# Altium schematic sheet Sheet 2 - Moteurs.SchDoc("Sheet 2 - Moteurs")
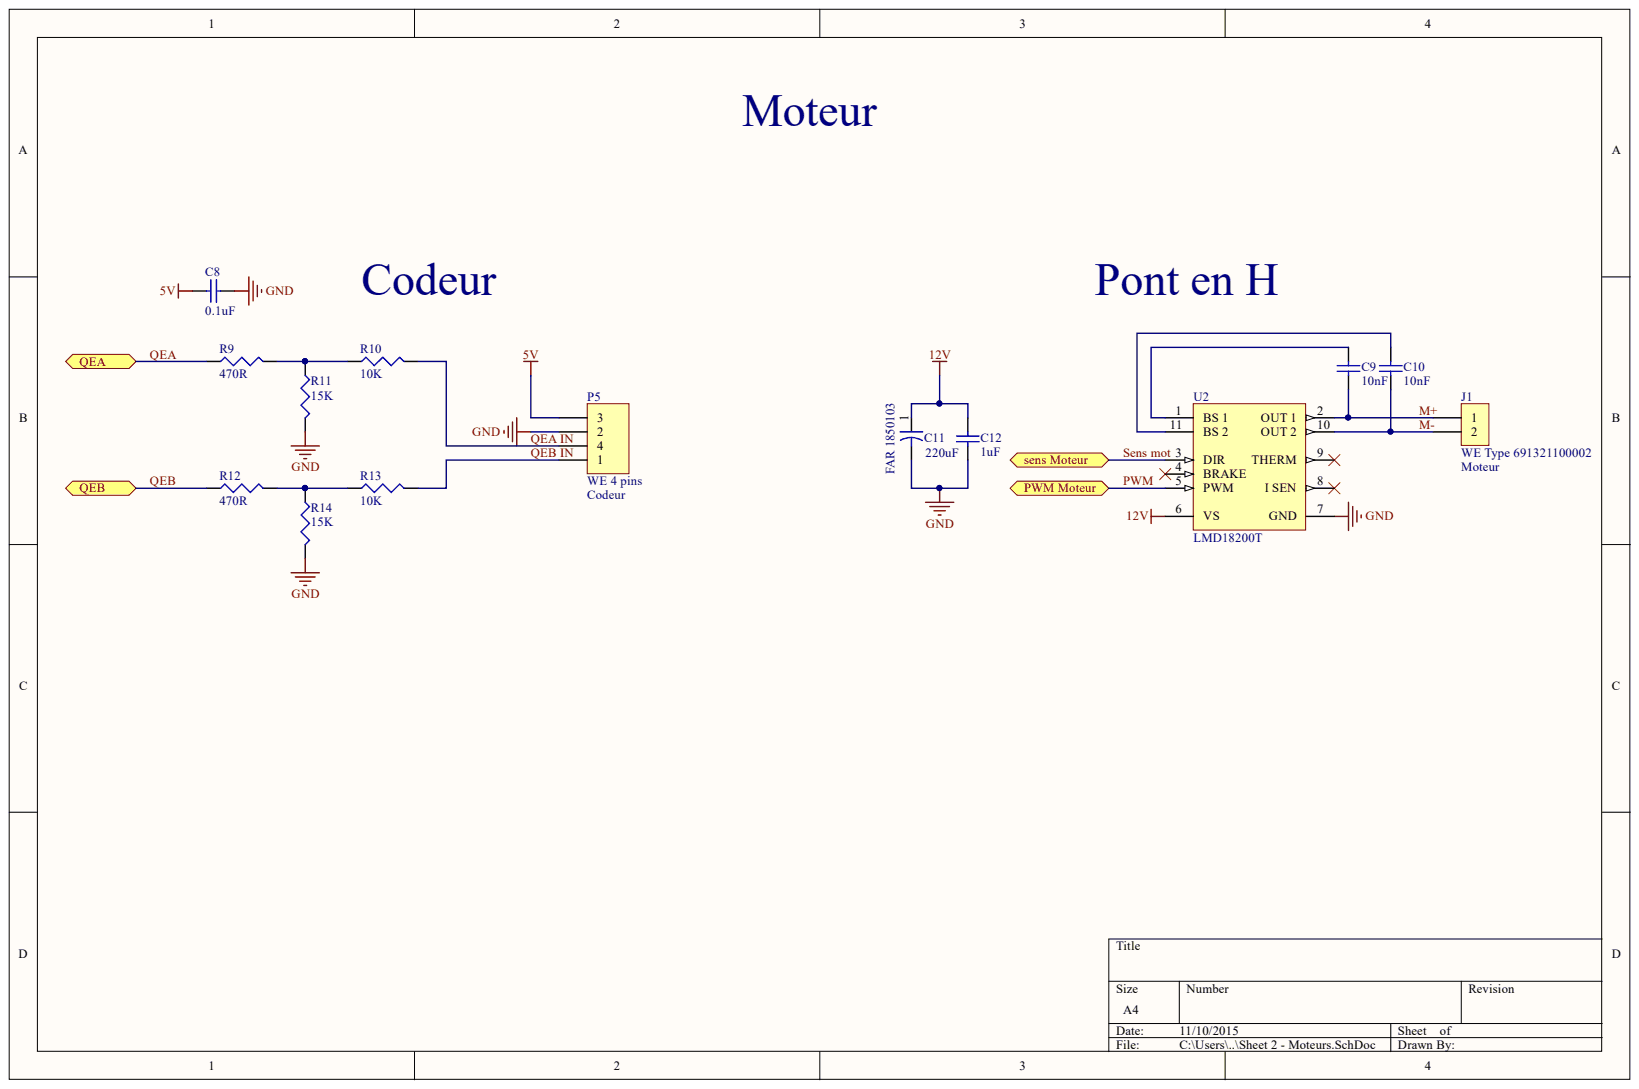
[diagram p0: Sheet 2 - Moteurs.SchDoc]

## Parts
C8  0.1uF  [C0805]
C9  10nF  [C0805]
C10  10nF  [C0805]
C11  220uF  réference commande: FAR 1850103  [Capa CMS D6.3_E1.8]
C12  1uF  [C0805]
J1  WE Type 691321100002  value Moteur  [691321100002]
P5  WE 4 pins  value Codeur  [690367280476]
R9  470R  [0805]
R10  10K  [0805]
R11  15K  [0805]
R12  470R  [0805]
R13  10K  [0805]
R14  15K  [0805]
U2  LMD18200T  [TA11B]

## Ports
PWM MOTEUR, QEA, QEB, SENS MOTEUR

## Nets
5V: C8.2 P5.3
12V: C11.1 C12.2 U2.6(VS)
GND: C8.1 C11.2 C12.1 P5.2 R11.2 R14.2 U2.7(GND)
M+: C9.2 J1.1 U2.2(OUT_1)
M-: C10.2 J1.2 U2.10(OUT_2)
PWM: U2.5(PWM) ; port PWM MOTEUR
QEA: R9.1 ; port QEA
QEA IN: P5.4 R10.2
QEB: R12.1 ; port QEB
QEB IN: P5.1 R13.2
SENS MOT: U2.3(DIR) ; port SENS MOTEUR
NetC9_1: C9.1 U2.1(BS_1)
NetC10_1: C10.1 U2.11(BS_2)
NetR9_2: R9.2 R10.1 R11.1
NetR12_2: R12.2 R13.1 R14.1

Not connected: U2 4(BRAKE) 8(I_SEN) 9(THERM)

## Library data of the parts
C8-C10 C12 = Cap: Capacitor 0805; Component Kind: Standard; LatestRevisionDate: 17-Jul-2002; LatestRevisionNote: Re-released for DXP Platform.; Library Name: Miscellaneous Devices.IntLib; PackageReference: RAD-0.3; Published: 8-Jun-2000; Signal Integrity: Cap; Simulation: CAP; Publisher: Altium Limited
  C8: value: 0.1uF
  C9 C10: Value: 10nF
  C12: Value: 1uF
C11 = Cap2: EEEFK1C221XP; Component Kind: Standard; Library Name: Miscellaneous Devices.IntLib; PackageReference: CAPR5-4X5; Published: 23-Sep-2002; Signal Integrity: Cap; Simulation: CAP; Value: 220uF; Publisher: Altium Limited
J1 = WE-Terminal Blocks Plugable Type 691321100002: WR-TBL Terminal block - serie 3211 - 3.50 mm; Manufacturer: W¨¹rth Elektronik eiSos GmbH; ComponentLink1 Description: Manufacturer; ComponentLink2 Description: Datasheet; NoPins: 2; Component Kind: Standard; ComponentLink1URL: http://www.we-online.com; ComponentLink2URL: http://katalog.we-online.de/em/datasheet/6913211000xx.pdf; Created Date: 9 December 2013; Library Name: 6913211000xx.IntLib; partNo: 691321100002; Created by: Karrer Zheng
P5 = MHDR1X4: Header, 4-Pin; Component Kind: Standard; LatestRevisionDate: 17-Jul-2002; LatestRevisionNote: Re-released for DXP Platform.; Library Name: Miscellaneous Connectors.IntLib; Signal Integrity: Connector; Publisher: Altium Limited
R9-R11 = Res3: Resistor 0805; Component Kind: Standard; LatestRevisionDate: 29-May-2009; LatestRevisionNote: IPC-7351 Footprint Added.; Library Name: Miscellaneous Devices.IntLib; PackageDescription: Chip Resistor; PackageReference: J1-0603; Published: 8-Jun-2000; Signal Integrity: Res; Simulation: RESISTOR; Publisher: Altium Limited
  R9: Value: 470R
  R10: Value: 10K
  R11: Value: 15k
R12-R14 = Res3: Resistor 0805; Value: 1K; Component Kind: Standard; LatestRevisionDate: 29-May-2009; LatestRevisionNote: IPC-7351 Footprint Added.; Library Name: Miscellaneous Devices.IntLib; PackageDescription: Chip Resistor; PackageReference: J1-0603; Published: 8-Jun-2000; Signal Integrity: Res; Simulation: RESISTOR; Publisher: Altium Limited
U2 = LMD18200T: 3A, 55V H-Bridge; Manufacturer: National Semiconductor; CompType: MiscPower; PackageTopMarking: NSUZXYTTE#*LMD18200T*P+; PowerWise: N; Component Kind: Standard; ComponentLink1Description: LMD18200 Datasheet; ComponentLink1URL: http://www.national.com/ds/LM/LMD18200.pdf; ComponentLink2Description: LMD18200 Web Page; ComponentLink2URL: http://www.national.com/pf/LM/LMD18200.html; ComponentLink3Description: TA11B Package Page; ComponentLink3URL: http://www.national.com/packaging/folders/ta11b.html; DatasheetVersion: Apr-2005; DigiKeyPartNumber: LMD18200T-ND; FirstPublished: 4-Dec-2008; Library Name: NSC MiscPower.IntLib; PackageDescription: TO-220, Molded, .788 x .421in Body, 11 Lead, .067in Pitch; PackageReference: TA11B; PackageVersion: revA, Mar-1987; PartNumber: LMD18200T; PinCount: 11; RoHS: O; Publisher: Altium Limited
